# Altium schematic sheet AC_BASE-V2.0.SchDoc(AC_BASE-V2.0)
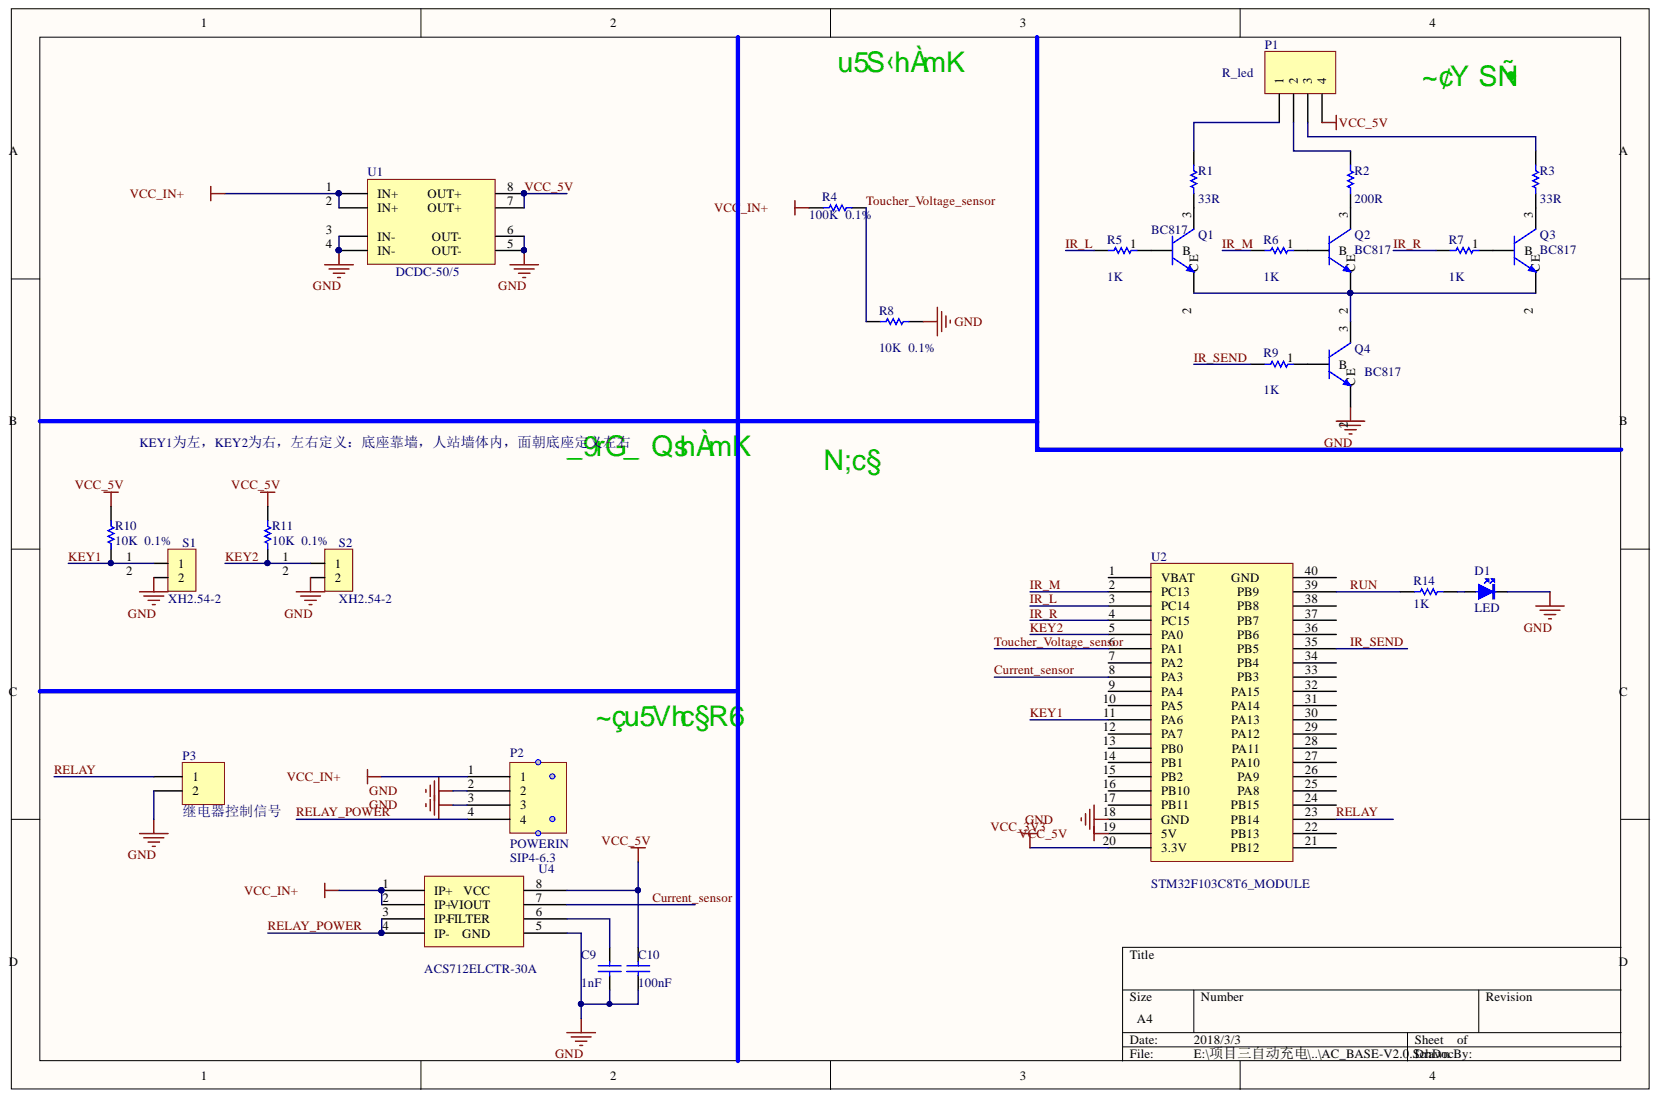
[diagram p0: AC_BASE-V2.0.SchDoc]

## Parts
C9  value 1nF  [C0805]  (hidden comment: Cap)
C10  value 100nF  [C0805]  (hidden comment: Cap)
D1  LED  [LED-H-R2.54]
P1  R_led  [XH2.54-4P]
P2  POWERIN  value SIP4-6.3  [PORT-SIP4-6.3-WA-LOCK]
P3  继电器控制信号  [XH2.54-2P]
Q1  BC817  [SOT-23_N]
Q2  BC817  [SOT-23_N]
Q3  BC817  [SOT-23_N]
Q4  BC817  [SOT-23_N]
R1  value 33R  [R0805]  (hidden comment: Res1)
R2  value 200R  [R0805]  (hidden comment: Res1)
R3  value 33R  [R0805]  (hidden comment: Res1)
R4  value 100K  0.1%  [R0805]  (hidden comment: Res1)
R5  value 1K  [R0805]  (hidden comment: Res1)
R6  value 1K  [R0805]  (hidden comment: Res1)
R7  value 1K  [R0805]  (hidden comment: Res1)
R8  value 10K  0.1%  [R0805]  (hidden comment: Res1)
R9  value 1K  [R0805]  (hidden comment: Res1)
R10  value 10K  0.1%  [R0805]  (hidden comment: Res1)
R11  value 10K  0.1%  [R0805]  (hidden comment: Res1)
R14  value 1K  [R0805]  (hidden comment: Res1)
S1  value XH2.54-2  [XH2.54-2P]  (hidden comment: ON)
S2  value XH2.54-2  [XH2.54-2P]  (hidden comment: ON)
U1  DCDC-50/5  [DCDC50/5]
U2  STM32F103C8T6_MODULE  [STM32F103C8T6_MODE]
U4  ACS712ELCTR-30A  [ACS712-SOIC8]

## Nets
GND: C9.1 C10.1 D1.2 P2.2 P2.3 P3.2 Q4.2 R8.2 S1.2 S2.2 U1.3(IN-) U1.4(IN-) U1.5 U1.6 U2.18(GND) U4.5
VCC_3V3: U2.20(3.3V)
VCC_IN+: P2.1 R4.1 U1.1(IN+) U1.2(IN+) U4.1(IP+) U4.2(IP+)
CURRENT_SENSOR: U2.8(PA3) U4.7
IR_L: R5.1 U2.3(PC14)
IR_M: R6.1 U2.2(PC13)
IR_R: R7.1 U2.4(PC15)
IR_SEND: R9.1 U2.35
KEY1: R10.1 S1.1 U2.11(PA6)
KEY2: R11.1 S2.1 U2.5(PA0)
RELAY: P3.1 U2.23
RELAY_POWER: P2.4 U4.3(IP-) U4.4(IP-)
RUN: R14.2 U2.39
TOUCHER_VOLTAGE_SENSOR: R4.2 R8.1 U2.6(PA1)
VCC_5V: C10.2 P1.4 R10.2 R11.2 U1.7 U1.8 U2.19(5V) U4.8
NetC9_2: C9.2 U4.6
NetD1_1: D1.1 R14.1
NetP1_1: P1.1 R1.2
NetP1_2: P1.2 R2.2
NetP1_3: P1.3 R3.2
NetQ1_1: Q1.1 R5.2
NetQ1_2: Q1.2 Q2.2 Q3.2 Q4.3
NetQ1_3: Q1.3 R1.1
NetQ2_1: Q2.1 R6.2
NetQ2_3: Q2.3 R2.1
NetQ3_1: Q3.1 R7.2
NetQ3_3: Q3.3 R3.1
NetQ4_1: Q4.1 R9.2

Not connected: U2 1(VBAT) 7(PA2) 9(PA4) 10(PA5) 12(PA7) 13(PB0) 14(PB1) 15(PB2) 16(PB10) 17(PB11) 21 22 24 25 26 27 28 29 30 31 32 33 34 36 37 38 40

## Library data of the parts
C9 C10 = Cap: Capacitor; Component Kind: Standard; LatestRevisionDate: 17-Jul-2002; LatestRevisionNote: Re-released for DXP Platform.; PackageDescription: Radial Cap, Thru-Hole; 2 Leads; 0.3 in Pin Spacing; PackageReference: RAD-0.3; Published: 8-Jun-2000; Library Name: boocax_schlib.SchLib; Publisher: Altium Limited
D1 = LED: Value: LED-H-R2.54; Component Kind: Standard; Description: LED; Library Name: boocax_schlib-20171017-1-dkw.SchLib
P1 = Header 4: Header, 4-Pin; Value: XH2.54-4; Component Kind: Standard; LatestRevisionDate: 17-Jul-2002; LatestRevisionNote: Re-released for DXP Platform.; Library Name: Miscellaneous Connectors.IntLib; Signal Integrity: Connector; Publisher: Altium Limited
P2 = CONN_4PIN: Value: SIP4-6.3; Component Kind: Standard; Library Name: boocax_schlib.SchLib
P3 = Header 2: Header, 2-Pin; Value: XH2.54-2; Component Kind: Standard; LatestRevisionDate: 17-Jul-2002; LatestRevisionNote: Re-released for DXP Platform.; Library Name: Miscellaneous Connectors.IntLib; Publisher: Altium Limited
Q1-Q4 = mNPN: NPN 45V 功耗 310mW; Component Kind: Standard; Library Name: Schlib1.SchLib; Value: BC817
R1-R9 R14 = Res1: Resistor; Component Kind: Standard; LatestRevisionDate: 17-Jul-2002; LatestRevisionNote: Re-released for DXP Platform.; PackageDescription: Axial Device, Thru-Hole; 2 Leads; 0.3 in Pin Spacing; PackageReference: AXIAL-0.3; Published: 8-Jun-2000; Signal Integrity: Res; Simulation: RESISTOR; Library Name: boocax_schlib-20171017-1-dkw.SchLib; Publisher: Altium Limited
R10 R11 = Res1: Resistor; Value: 10K  0.1%; Component Kind: Standard; LatestRevisionDate: 17-Jul-2002; LatestRevisionNote: Re-released for DXP Platform.; PackageDescription: Axial Device, Thru-Hole; 2 Leads; 0.3 in Pin Spacing; PackageReference: AXIAL-0.3; Published: 8-Jun-2000; Signal Integrity: Res; Simulation: RESISTOR; Library Name: boocax_schlib-20171017-1-dkw.SchLib; Publisher: Altium Limited
S1 S2 = Conn_1*2: Component Kind: Standard; Library Name: boocax_schlib.SchLib
U2 = STM32F103C8T6_MODE: Component Kind: Standard; Value: STM32F103C8T6_MODULE; Library Name: boocax_schlib.SchLib
U4 = ACS712: Component Kind: Standard; value: ACS712ELCTR-30A; Library Name: boocax_schlib.SchLib
U1 = DCDC-50/5: Component Kind: Standard; Description: DCDC-50/5; Value: DCDC-50/5; Library Name: boocax_schlib.SCHLIB
